# Altium schematic sheet 015_DDR3.SchDoc(015_DDR3)
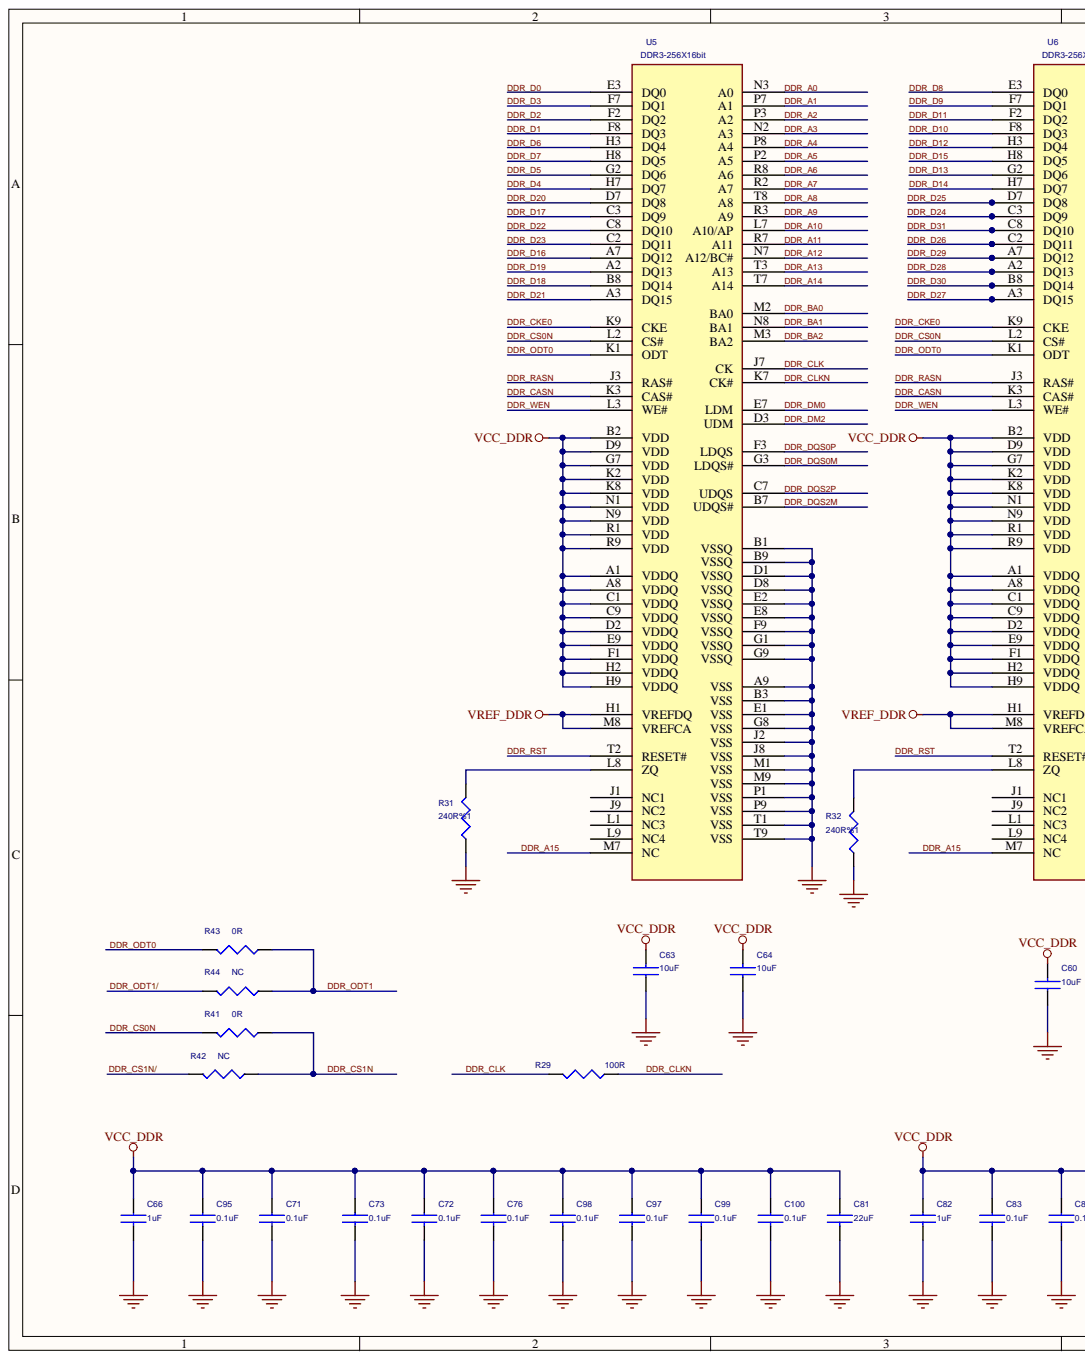
[diagram p0: 015_DDR3.SchDoc, left]
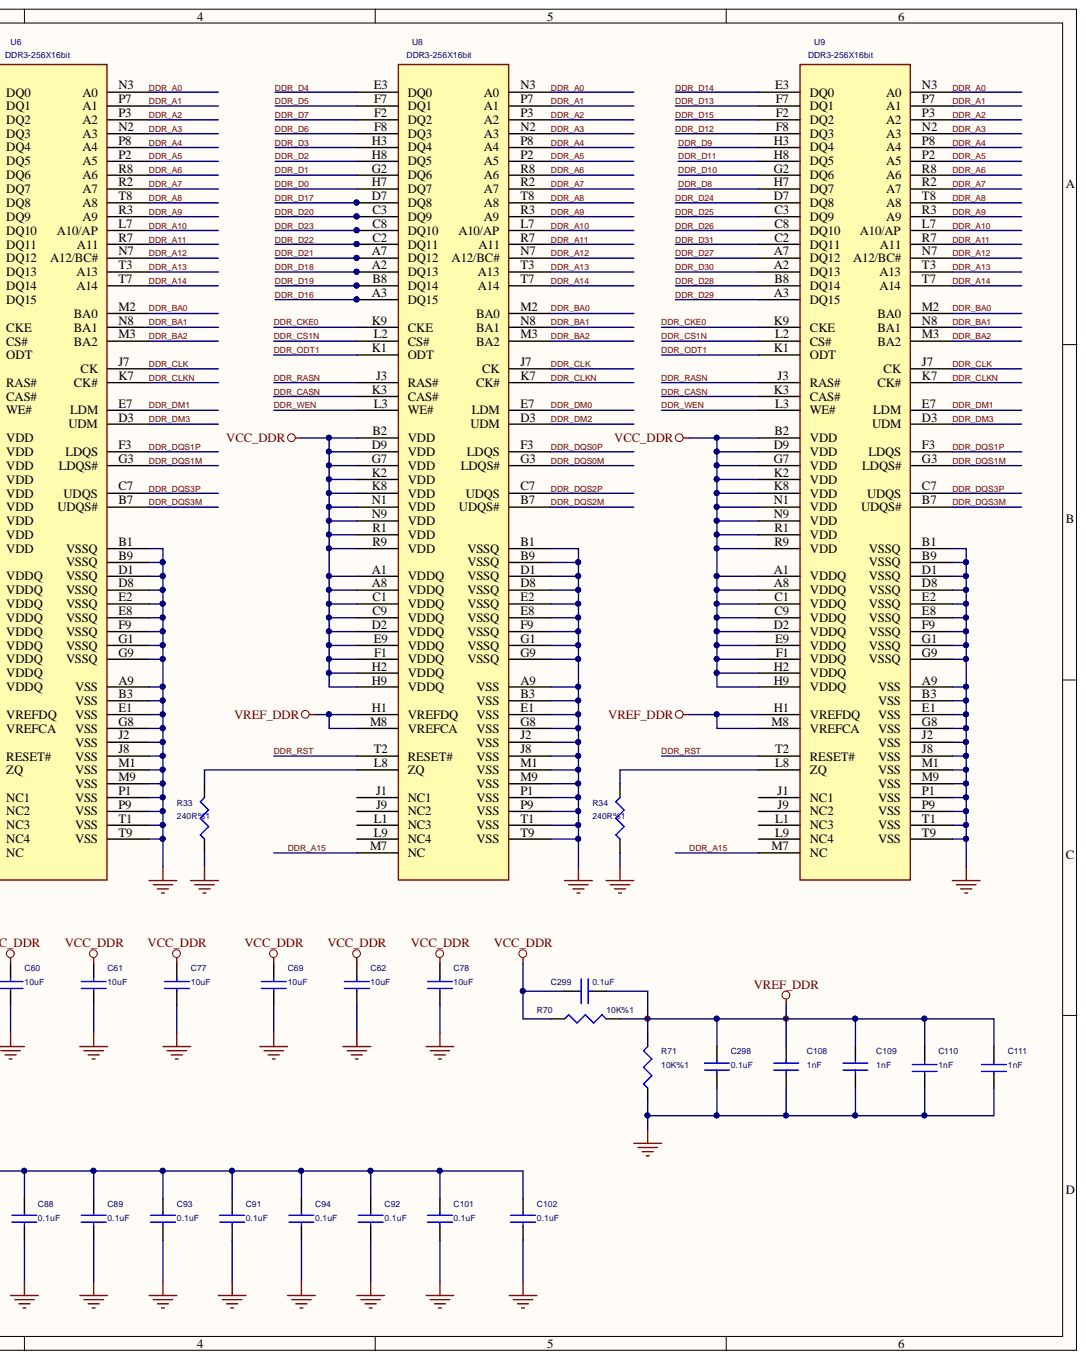
[diagram p1: 015_DDR3.SchDoc, right]

## Parts
C60  10uF  [C0603]
C61  10uF  [C0402]
C62  10uF  [C0603]
C63  10uF  [C0402]
C64  10uF  [C0402]
C66  1uF  [C0402]
C69  10uF  [C0402]
C71  0.1uF  [C0402]
C72  0.1uF  [C0402]
C73  0.1uF  [C0402]
C76  0.1uF  [C0402]
C77  10uF  [C0402]
C78  10uF  [C0402]
C81  22uF  [C0402]
C82  1uF  [C0402]
C83  0.1uF  [C0402]
C88  0.1uF  [C0402]
C89  0.1uF  [C0402]
C91  0.1uF  [C0402]
C92  0.1uF  [C0402]
C93  0.1uF  [C0402]
C94  0.1uF  [C0402]
C95  0.1uF  [C0402]
C97  0.1uF  [C0402]
C98  0.1uF  [C0402]
C99  0.1uF  [C0402]
C100  0.1uF  [C0402]
C101  0.1uF  [C0402]
C102  0.1uF  [C0402]
C108  1nF  [C0402]
C109  1nF  [C0402]
C110  1nF  [C0402]
C111  1nF  [C0402]
C298  0.1uF  [C0402]
C299  0.1uF  [C0402]
R29  100R  [R0402]
R31  240R%1  [R0402]
R32  240R%1  [R0402]
R33  240R%1  [R0402]
R34  240R%1  [R0402]
R41  0R  [R0402]
R42  NC  [R0402]
R43  0R  [R0402]
R44  NC  [R0402]
R70  10K%1  [R0402]
R71  10K%1  [R0402]
U5  DDR3-256X16bit  [DDRII_X16]
U6  DDR3-256X16bit  [DDRII_X16]
U8  DDR3-256X16bit  [DDRII_X16]
U9  DDR3-256X16bit  [DDRII_X16]

## Nets
GND: C60.1 C61.1 C62.1 C63.1 C64.1 C66.2 C69.1 C71.2 C72.2 C73.2 C76.2 C77.1 C78.1 C81.2 C82.2 C83.2 C88.2 C89.2 C91.2 C92.2 C93.2 C94.2 C95.2 C97.2 C98.2 C99.2 C100.2 C101.2 C102.2 C108.2 C109.2 C110.1 C111.1 C298.2 R31.1 R32.1 R33.1 R34.1 R71.1 U5.A9(VSS) U5.B1(VSSQ) U5.B3(VSS) U5.B9(VSSQ) U5.D1(VSSQ) U5.D8(VSSQ) U5.E1(VSS) U5.E2(VSSQ) U5.E8(VSSQ) U5.F9(VSSQ) U5.G1(VSSQ) U5.G8(VSS) U5.G9(VSSQ) U5.J2(VSS) U5.J8(VSS) U5.M1(VSS) U5.M9(VSS) U5.P1(VSS) U5.P9(VSS) U5.T1(VSS) U5.T9(VSS) U6.A9(VSS) U6.B1(VSSQ) U6.B3(VSS) U6.B9(VSSQ) U6.D1(VSSQ) U6.D8(VSSQ) U6.E1(VSS) U6.E2(VSSQ) U6.E8(VSSQ) U6.F9(VSSQ) U6.G1(VSSQ) U6.G8(VSS) U6.G9(VSSQ) U6.J2(VSS) U6.J8(VSS) U6.M1(VSS) U6.M9(VSS) U6.P1(VSS) U6.P9(VSS) U6.T1(VSS) U6.T9(VSS) U8.A9(VSS) U8.B1(VSSQ) U8.B3(VSS) U8.B9(VSSQ) U8.D1(VSSQ) U8.D8(VSSQ) U8.E1(VSS) U8.E2(VSSQ) U8.E8(VSSQ) U8.F9(VSSQ) U8.G1(VSSQ) U8.G8(VSS) U8.G9(VSSQ) U8.J2(VSS) U8.J8(VSS) U8.M1(VSS) U8.M9(VSS) U8.P1(VSS) U8.P9(VSS) U8.T1(VSS) U8.T9(VSS) U9.A9(VSS) U9.B1(VSSQ) U9.B3(VSS) U9.B9(VSSQ) U9.D1(VSSQ) U9.D8(VSSQ) U9.E1(VSS) U9.E2(VSSQ) U9.E8(VSSQ) U9.F9(VSSQ) U9.G1(VSSQ) U9.G8(VSS) U9.G9(VSSQ) U9.J2(VSS) U9.J8(VSS) U9.M1(VSS) U9.M9(VSS) U9.P1(VSS) U9.P9(VSS) U9.T1(VSS) U9.T9(VSS)
VCC_DDR: C60.2 C61.2 C62.2 C63.2 C64.2 C66.1 C69.2 C71.1 C72.1 C73.1 C76.1 C77.2 C78.2 C81.1 C82.1 C83.1 C88.1 C89.1 C91.1 C92.1 C93.1 C94.1 C95.1 C97.1 C98.1 C99.1 C100.1 C101.1 C102.1 C299.1 R70.1 U5.A1(VDDQ) U5.A8(VDDQ) U5.B2(VDD) U5.C1(VDDQ) U5.C9(VDDQ) U5.D2(VDDQ) U5.D9(VDD) U5.E9(VDDQ) U5.F1(VDDQ) U5.G7(VDD) U5.H2(VDDQ) U5.H9(VDDQ) U5.K2(VDD) U5.K8(VDD) U5.N1(VDD) U5.N9(VDD) U5.R1(VDD) U5.R9(VDD) U6.A1(VDDQ) U6.A8(VDDQ) U6.B2(VDD) U6.C1(VDDQ) U6.C9(VDDQ) U6.D2(VDDQ) U6.D9(VDD) U6.E9(VDDQ) U6.F1(VDDQ) U6.G7(VDD) U6.H2(VDDQ) U6.H9(VDDQ) U6.K2(VDD) U6.K8(VDD) U6.N1(VDD) U6.N9(VDD) U6.R1(VDD) U6.R9(VDD) U8.A1(VDDQ) U8.A8(VDDQ) U8.B2(VDD) U8.C1(VDDQ) U8.C9(VDDQ) U8.D2(VDDQ) U8.D9(VDD) U8.E9(VDDQ) U8.F1(VDDQ) U8.G7(VDD) U8.H2(VDDQ) U8.H9(VDDQ) U8.K2(VDD) U8.K8(VDD) U8.N1(VDD) U8.N9(VDD) U8.R1(VDD) U8.R9(VDD) U9.A1(VDDQ) U9.A8(VDDQ) U9.B2(VDD) U9.C1(VDDQ) U9.C9(VDDQ) U9.D2(VDDQ) U9.D9(VDD) U9.E9(VDDQ) U9.F1(VDDQ) U9.G7(VDD) U9.H2(VDDQ) U9.H9(VDDQ) U9.K2(VDD) U9.K8(VDD) U9.N1(VDD) U9.N9(VDD) U9.R1(VDD) U9.R9(VDD)
VREF_DDR: C108.1 C109.1 C110.2 C111.2 C298.1 C299.2 R70.2 R71.2 U5.H1(VREFDQ) U5.M8(VREFCA) U6.H1(VREFDQ) U6.M8(VREFCA) U8.H1(VREFDQ) U8.M8(VREFCA) U9.H1(VREFDQ) U9.M8(VREFCA)
DDR_A0: U5.N3(A0) U6.N3(A0) U8.N3(A0) U9.N3(A0)
DDR_A1: U5.P7(A1) U6.P7(A1) U8.P7(A1) U9.P7(A1)
DDR_A2: U5.P3(A2) U6.P3(A2) U8.P3(A2) U9.P3(A2)
DDR_A3: U5.N2(A3) U6.N2(A3) U8.N2(A3) U9.N2(A3)
DDR_A4: U5.P8(A4) U6.P8(A4) U8.P8(A4) U9.P8(A4)
DDR_A5: U5.P2(A5) U6.P2(A5) U8.P2(A5) U9.P2(A5)
DDR_A6: U5.R8(A6) U6.R8(A6) U8.R8(A6) U9.R8(A6)
DDR_A7: U5.R2(A7) U6.R2(A7) U8.R2(A7) U9.R2(A7)
DDR_A8: U5.T8(A8) U6.T8(A8) U8.T8(A8) U9.T8(A8)
DDR_A9: U5.R3(A9) U6.R3(A9) U8.R3(A9) U9.R3(A9)
DDR_A10: U5.L7(A10/AP) U6.L7(A10/AP) U8.L7(A10/AP) U9.L7(A10/AP)
DDR_A11: U5.R7(A11) U6.R7(A11) U8.R7(A11) U9.R7(A11)
DDR_A12: U5.N7(A12/BC#) U6.N7(A12/BC#) U8.N7(A12/BC#) U9.N7(A12/BC#)
DDR_A13: U5.T3(A13) U6.T3(A13) U8.T3(A13) U9.T3(A13)
DDR_A14: U5.T7(A14) U6.T7(A14) U8.T7(A14) U9.T7(A14)
DDR_A15: U5.M7(NC) U6.M7(NC) U8.M7(NC) U9.M7(NC)
DDR_BA0: U5.M2(BA0) U6.M2(BA0) U8.M2(BA0) U9.M2(BA0)
DDR_BA1: U5.N8(BA1) U6.N8(BA1) U8.N8(BA1) U9.N8(BA1)
DDR_BA2: U5.M3(BA2) U6.M3(BA2) U8.M3(BA2) U9.M3(BA2)
DDR_CASN: U5.K3(CAS#) U6.K3(CAS#) U8.K3(CAS#) U9.K3(CAS#)
DDR_CKE0: U5.K9(CKE) U6.K9(CKE) U8.K9(CKE) U9.K9(CKE)
DDR_CLK: R29.2 U5.J7(CK) U6.J7(CK) U8.J7(CK) U9.J7(CK)
DDR_CLKN: R29.1 U5.K7(CK#) U6.K7(CK#) U8.K7(CK#) U9.K7(CK#)
DDR_CS0N: R41.1 U5.L2(CS#) U6.L2(CS#)
DDR_CS1N: R41.2 R42.2 U8.L2(CS#) U9.L2(CS#)
DDR_CS1N/: R42.1
DDR_D0: U5.E3(DQ0) U8.H7(DQ7)
DDR_D1: U5.F8(DQ3) U8.G2(DQ6)
DDR_D2: U5.F2(DQ2) U8.H8(DQ5)
DDR_D3: U5.F7(DQ1) U8.H3(DQ4)
DDR_D4: U5.H7(DQ7) U8.E3(DQ0)
DDR_D5: U5.G2(DQ6) U8.F7(DQ1)
DDR_D6: U5.H3(DQ4) U8.F8(DQ3)
DDR_D7: U5.H8(DQ5) U8.F2(DQ2)
DDR_D8: U6.E3(DQ0) U9.H7(DQ7)
DDR_D9: U6.F7(DQ1) U9.H3(DQ4)
DDR_D10: U6.F8(DQ3) U9.G2(DQ6)
DDR_D11: U6.F2(DQ2) U9.H8(DQ5)
DDR_D12: U6.H3(DQ4) U9.F8(DQ3)
DDR_D13: U6.G2(DQ6) U9.F7(DQ1)
DDR_D14: U6.H7(DQ7) U9.E3(DQ0)
DDR_D15: U6.H8(DQ5) U9.F2(DQ2)
DDR_D16: U5.A7(DQ12) U8.A3(DQ15)
DDR_D17: U5.C3(DQ9) U8.D7(DQ8)
DDR_D18: U5.B8(DQ14) U8.A2(DQ13)
DDR_D19: U5.A2(DQ13) U8.B8(DQ14)
DDR_D20: U5.D7(DQ8) U8.C3(DQ9)
DDR_D21: U5.A3(DQ15) U8.A7(DQ12)
DDR_D22: U5.C8(DQ10) U8.C2(DQ11)
DDR_D23: U5.C2(DQ11) U8.C8(DQ10)
DDR_D24: U6.C3(DQ9) U9.D7(DQ8)
DDR_D25: U6.D7(DQ8) U9.C3(DQ9)
DDR_D26: U6.C2(DQ11) U9.C8(DQ10)
DDR_D27: U6.A3(DQ15) U9.A7(DQ12)
DDR_D28: U6.A2(DQ13) U9.B8(DQ14)
DDR_D29: U6.A7(DQ12) U9.A3(DQ15)
DDR_D30: U6.B8(DQ14) U9.A2(DQ13)
DDR_D31: U6.C8(DQ10) U9.C2(DQ11)
DDR_DM0: U5.E7(LDM) U8.E7(LDM)
DDR_DM1: U6.E7(LDM) U9.E7(LDM)
DDR_DM2: U5.D3(UDM) U8.D3(UDM)
DDR_DM3: U6.D3(UDM) U9.D3(UDM)
DDR_DQS0M: U5.G3(LDQS#) U8.G3(LDQS#)
DDR_DQS0P: U5.F3(LDQS) U8.F3(LDQS)
DDR_DQS1M: U6.G3(LDQS#) U9.G3(LDQS#)
DDR_DQS1P: U6.F3(LDQS) U9.F3(LDQS)
DDR_DQS2M: U5.B7(UDQS#) U8.B7(UDQS#)
DDR_DQS2P: U5.C7(UDQS) U8.C7(UDQS)
DDR_DQS3M: U6.B7(UDQS#) U9.B7(UDQS#)
DDR_DQS3P: U6.C7(UDQS) U9.C7(UDQS)
DDR_ODT0: R43.1 U5.K1(ODT) U6.K1(ODT)
DDR_ODT1: R43.2 R44.2 U8.K1(ODT) U9.K1(ODT)
DDR_ODT1/: R44.1
DDR_RASN: U5.J3(RAS#) U6.J3(RAS#) U8.J3(RAS#) U9.J3(RAS#)
DDR_RST: U5.T2(RESET#) U6.T2(RESET#) U8.T2(RESET#) U9.T2(RESET#)
DDR_WEN: U5.L3(WE#) U6.L3(WE#) U8.L3(WE#) U9.L3(WE#)
NetR31_2: R31.2 U5.L8(ZQ)
NetR32_2: R32.2 U6.L8(ZQ)
NetR33_2: R33.2 U8.L8(ZQ)
NetR34_2: R34.2 U9.L8(ZQ)

Not connected: U5 J1(NC1) J9(NC2) L1(NC3) L9(NC4); U6 J1(NC1) J9(NC2) L1(NC3) L9(NC4); U8 J1(NC1) J9(NC2) L1(NC3) L9(NC4); U9 J1(NC1) J9(NC2) L1(NC3) L9(NC4)

## Library data of the parts
C60-C64 C69 C77 C78 = CAP NP_Dup4: RoHS CHIP CAP 10uF +10/-10% X5R; Component Kind: Standard
C66 C82 C95 C102 = CAP NP_Dup4: RoHS CHIP CAP 1uF +10/-10% X5R; Component Kind: Standard
C71-C73 C76 C81 C83 C88 C89 C91-C94 C97-C101 = CAP NP_Dup4: RoHS CHIP CAP 0.1uF +10/-10% X7R; Component Kind: Standard
C108-C111 C298 C299 = CAP NP_0: Component Kind: Standard
R29 = RESISTOR: NC/ROHS CHIP RES 100R 1/16W 5%; Component Kind: Standard
R31-R34 = RESISTOR_0_Dup1: RES,thick film,240Ohm,+/-5%,1/16W,SMD0402; Component Kind: Standard
R41-R44 = RES 1B: Component Kind: Standard
R70 R71 = RESISTOR_0_Dup1: 뚬롯量; Component Kind: Standard
U5 U6 U8 U9 = DDRII_3: Component Kind: Standard
